# Altium schematic sheet Sheet1.SchDoc(Sheet1)
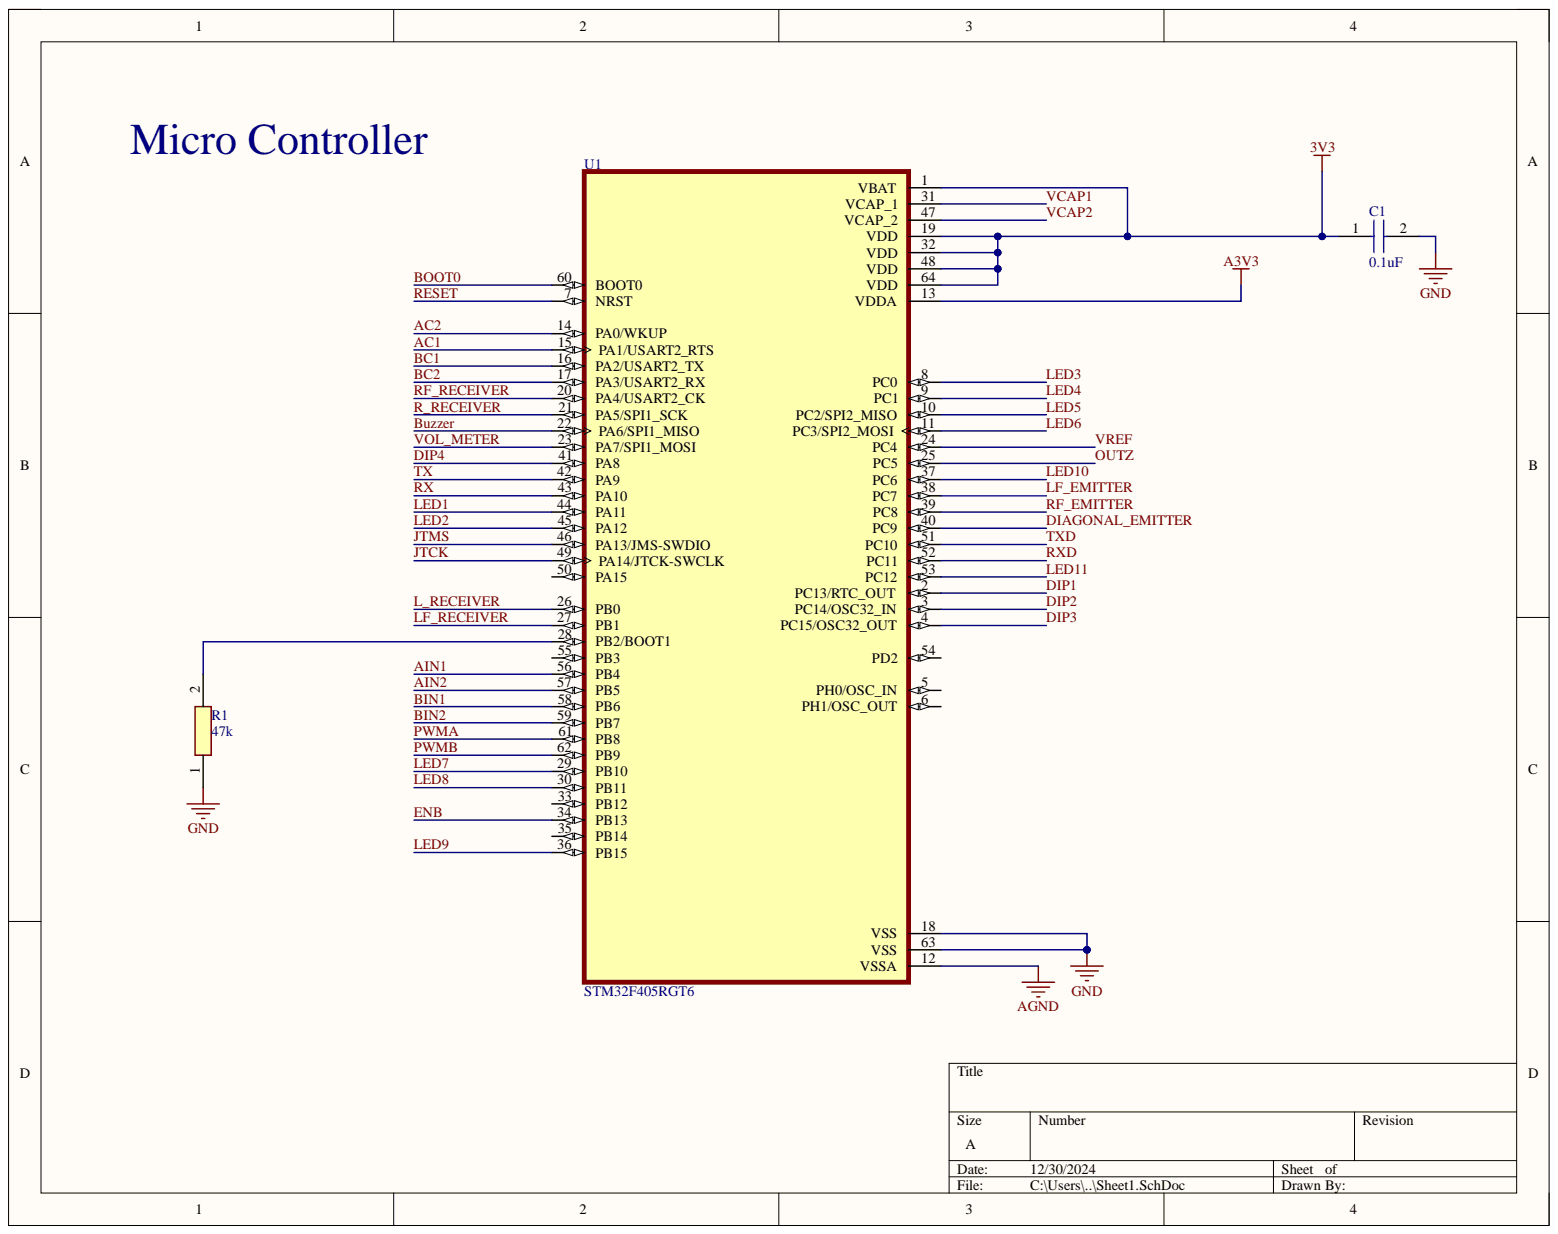
[diagram p0: Sheet1.SchDoc]

## Parts
C1  0.1uF  [CAPC1005X55N]
R1  47k  [ERA2AED122X]
U1  STM32F405RGT6  [STM32F405RGT6]

## Nets
3V3: C1.1 U1.1(VBAT) U1.19(VDD) U1.32(VDD) U1.48(VDD) U1.64(VDD)
A3V3: U1.13(VDDA)
AGND: U1.12(VSSA)
GND: C1.2 R1.1 U1.18(VSS) U1.63(VSS)
AC1: U1.15(PA1/USART2_RTS)
AC2: U1.14(PA0/WKUP)
AIN1: U1.56(PB4)
AIN2: U1.57(PB5)
BC1: U1.16(PA2/USART2_TX)
BC2: U1.17(PA3/USART2_RX)
BIN1: U1.58(PB6)
BIN2: U1.59(PB7)
BOOT0: U1.60(BOOT0)
Buzzer: U1.22(PA6/SPI1_MISO)
DIAGONAL_EMITTER: U1.40(PC9)
DIP1: U1.2(PC13/RTC_OUT)
DIP2: U1.3(PC14/OSC32_IN)
DIP3: U1.4(PC15/OSC32_OUT)
DIP4: U1.41(PA8)
ENB: U1.34(PB13)
JTCK: U1.49(PA14/JTCK-SWCLK)
JTMS: U1.46(PA13/JMS-SWDIO)
L_RECEIVER: U1.26(PB0)
LED1: U1.44(PA11)
LED2: U1.45(PA12)
LED3: U1.8(PC0)
LED4: U1.9(PC1)
LED5: U1.10(PC2/SPI2_MISO)
LED6: U1.11(PC3/SPI2_MOSI)
LED7: U1.29(PB10)
LED8: U1.30(PB11)
LED9: U1.36(PB15)
LED10: U1.37(PC6)
LED11: U1.53(PC12)
LF_EMITTER: U1.38(PC7)
LF_RECEIVER: U1.27(PB1)
OUTZ: U1.25(PC5)
PWMA: U1.61(PB8)
PWMB: U1.62(PB9)
R_RECEIVER: U1.21(PA5/SPI1_SCK)
RESET: U1.7(NRST)
RF_EMITTER: U1.39(PC8)
RF_RECEIVER: U1.20(PA4/USART2_CK)
RX: U1.43(PA10)
RXD: U1.52(PC11)
TX: U1.42(PA9)
TXD: U1.51(PC10)
VCAP1: U1.31(VCAP_1)
VCAP2: U1.47(VCAP_2)
VOL_METER: U1.23(PA7/SPI1_MOSI)
VREF: U1.24(PC4)
NetR1_2: R1.2 U1.28(PB2/BOOT1)

Not connected: U1 5(PH0/OSC_IN) 6(PH1/OSC_OUT) 33(PB12) 35(PB14) 50(PA15) 54(PD2) 55(PB3)

## Library data of the parts
C1 = 0.1uF: 0.1uf SMD 25V cermaic; Manufacturer_Name: TAIYO YUDEN; Manufacturer_Part_Number: MBAST105SB7104KFNA01; Component Kind: Standard; Datasheet Link: https://ds.yuden.co.jp/TYCOMPAS/or/specSheet?pn=MBAST105SB7104KFNA01; Height: 0.55mm; Library Name: Schlib1.SchLib; Mouser Part Number: 963-BAST105SB7104KF; Mouser Price/Stock: https://www.mouser.co.uk/ProductDetail/TAIYO-YUDEN/MBAST105SB7104KFNA01?qs=tlsG%2FOw5FFj0FpJuqULGiw%3D%3D
R1 = 47k: Thin Film Resistors 47K OHM .1% 15PPM 1/16W; Manufacturer_Name: Panasonic; Manufacturer_Part_Number: ERA-2APB473X; Component Kind: Standard; Datasheet Link: http://industrial.panasonic.com/cdbs/www-data/pdf/RDM0000/AOA0000C307.pdf; Height: 0.4mm; Library Name: Schlib1.SchLib; Mouser Part Number: 667-ERA-2APB473X; Mouser Price/Stock: https://www.mouser.co.uk/ProductDetail/Panasonic/ERA-2APB473X?qs=PgLP5Altfow2TfhzIUmQPg%3D%3D
U1 = STM32F405RGT6: High-performance foundation line, ARM Cortex-M4 core with DSP and FPU, 1 Mbyte Flash, 168 MHz CPU, ART Accelerator; Availability: Unavailable; Component Kind: Standard; Library Name: Schlib1.SchLib; MP: STM32F405RGT6; Package: None; Price: None
